# Altium schematic sheet RS485_Isolator_2_click_v101.SchDoc(RS485_Isolator_2_click_v101)
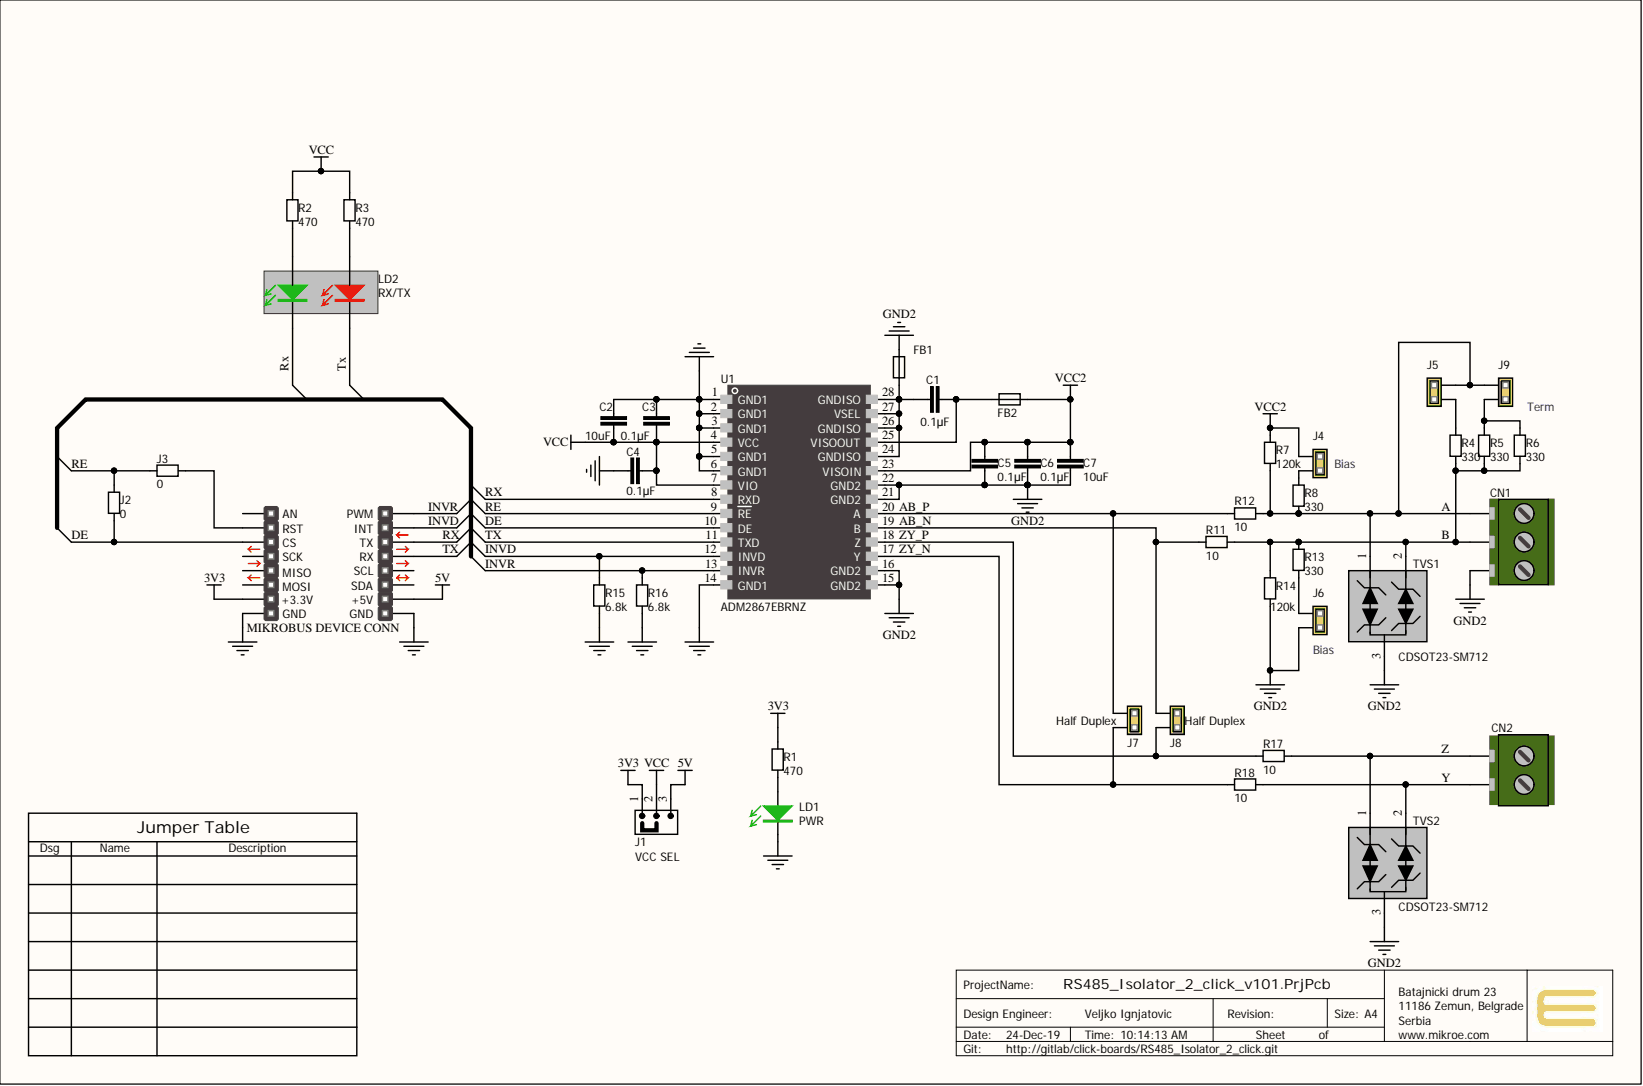
[diagram p0: RS485_Isolator_2_click_v101.SchDoc]

## Parts
C1  0.1µF  [CAPC1005X55N-0402-NoOverlay]
C2  10uF  [CAPC1005X55N-0402-NoOverlay]
C3  0.1µF  [CAPC1005X55N-0402-NoOverlay]
C4  0.1µF  [CAPC1005X55N-0402-NoOverlay]
C5  0.1µF  [CAPC1005X55N-0402-NoOverlay]
C6  0.1µF  [CAPC1005X55N-0402-NoOverlay]
C7  10uF  [CAPC1005X55N-0402-NoOverlay]
CN1  #NAME?  [SCREW TERMINAL 3P 2.54]  (value not drawn)
CN2  Manufacturer_Part_Number  [SCREW TERMINAL 2.54]  (value not drawn)
FB1  FERRITE  [INDC1005X60N-0402-NOOVERLAY]  (value not drawn)
FB2  FERRITE  [INDC1005X60N-0402-NOOVERLAY]  (value not drawn)
J1  VCC SEL  [JUMPER-0805-V2-12]
J2  0  [RESC2013X38N-0805-NOOVERLAY]  (no BOM)
J3  0  [RESC2013X38N-0805-NOOVERLAY]
J4  HM1x2 Jumper  [HDR1X2]  (value not drawn)
J5  HM1x2 Jumper  [HDR1X2]  (value not drawn)
J6  HM1x2 Jumper  [HDR1X2]  (value not drawn)
J7  Half Duplex  [HDR1X2]
J8  Half Duplex  [HDR1X2]
J9  HM1x2 Jumper  [HDR1X2]  (value not drawn)
LD1  PWR  [DIOGREENC2013X120N-0805-NoOverlay]
LD2  RX/TX  [LED0603RG - nooverlay]
MBD1  MIKROBUS DEVICE CONN  [New Click Template_LARGE-WG]  (no BOM)
R1  470  [RESC2013X38N-0805-NOOVERLAY]
R2  470  [RESC1005X40N-0402-NOOVERLAY]
R3  470  [RESC1005X40N-0402-NOOVERLAY]
R4  330  [RESC1005X40N-0402-NOOVERLAY]
R5  330  [RESC1005X40N-0402-NOOVERLAY]
R6  330  [RESC1005X40N-0402-NOOVERLAY]
R7  120k  [RESC1005X40N-0402-NOOVERLAY]
R8  330  [RESC1005X40N-0402-NOOVERLAY]
R11  10  [RESC1005X40N-0402-NOOVERLAY]
R12  10  [RESC1005X40N-0402-NOOVERLAY]
R13  330  [RESC1005X40N-0402-NOOVERLAY]
R14  120k  [RESC1005X40N-0402-NOOVERLAY]
R15  6.8k  [RESC1005X40N-0402-NOOVERLAY]
R16  6.8k  [RESC1005X40N-0402-NOOVERLAY]
R17  10  [RESC1005X40N-0402-NOOVERLAY]
R18  10  [RESC1005X40N-0402-NOOVERLAY]
TVS1  CDSOT23-SM712  [SOT95P240X110-3N]
TVS2  CDSOT23-SM712  [SOT95P240X110-3N]
U1  ADM2867EBRNZ  [SOP65P1030X265-28N-NoOverlay]

## Nets
3V3: J1.1 MBD1.7(+3.3V) R1.2
5V: J1.3 MBD1.10(+5V)
GND: C2.2 C3.2 C4.2 LD1.1 MBD1.8(GND) MBD1.9(GND) R15.2 R16.2 U1.1(GND1) U1.2(GND1) U1.3(GND1) U1.5(GND1) U1.6(GND1) U1.14(GND1)
GND2: C5.2 C6.2 C7.1 CN1.1 FB1.1 J6.1 R14.1 TVS1.3 TVS2.3 U1.15(GND2) U1.16(GND2) U1.21(GND2) U1.22(GND2)
VCC: C2.1 C3.1 C4.1 J1.2 R2.2 R3.2 U1.4(VCC) U1.7(VIO)
VCC2: C5.1 C6.1 C7.2 FB2.1 J4.1 R7.2 U1.23(VISOIN)
A: CN1.3 J5.1 J9.1 R7.1 R8.1 R12.2 TVS1.1
AB_N: J8.2 R11.1 U1.19(B)
AB_P: J7.2 R12.1 U1.20(A)
B: CN1.2 R4.1 R5.1 R6.1 R11.2 R13.2 R14.2 TVS1.2
DE: J2.1 MBD1.3(CS) U1.10(DE)
INVD: MBD1.15(INT) R15.1 U1.12(INVD)
INVR: MBD1.16(PWM) R16.1 U1.13(INVR)
RE: J2.2 J3.2 U1.9(RE)
Rx: LD2.3 MBD1.14(TX) U1.8(RXD)
Tx: LD2.4 MBD1.13(RX) U1.11(TXD)
Y: CN2.1 R18.2 TVS2.2
Z: CN2.2 R17.2 TVS2.1
ZY_N: J7.1 R18.1 U1.17(Y)
ZY_P: J8.1 R17.1 U1.18(Z)
NetC1_1: C1.1 FB1.2 U1.24(GNDISO) U1.26(GNDISO) U1.27(VSEL) U1.28(GNDISO)
NetC1_2: C1.2 FB2.2 U1.25(VISOOUT)
NetJ3_1: J3.1 MBD1.2(RST)
NetJ4_2: J4.2 R8.2
NetJ5_2: J5.2 R4.2
NetJ6_2: J6.2 R13.1
NetJ9_2: J9.2 R5.2 R6.2
NetLD1_2: LD1.2 R1.1
NetLD2_1: LD2.1 R2.1
NetLD2_2: LD2.2 R3.1
N00012:

Not connected: MBD1 1(AN) 4(SCK) 5(MISO) 6(MOSI) 11(SDA) 12(SCL)

## Library data of the parts
C1 C3-C6 = 2911010025755: CAP CER 0.1UF 10V X5R 0402; Manufacturer Part Number: LMK105BJ104KV-F; Manufacturer: Taiyo Yuden; Tolerance: ±10%; Recommended Rating: Platinum; item_id: 3484; id: 63; Database Table Name: capacitors; Assembly: SMD; Voltage Rated: 10V; Temperature Coefficient: X5R; Part Type: Capacitors; Package Case: 0402 (1005 Metric); Library Name: ME-Altium Lib.SVNDbLib; Family: CERAMIC CAPACITOR; Datasheet URL: http://ds.yuden.co.jp/TYCOMPAS/ut/detail.do?productNo=LMK105BJ104KV-F&fileName=LMK105BJ104KV-F_SS&mode=specSheetDownload; Component Kind: Standard; Category: Capacitors; Capacitance: 0.1µF; Barcode: 2911010025755
C2 C7 = 0100000099759: CAP CER 10UF 10V X5R 0402; Manufacturer Part Number: CL05A106MP5NUNC; Manufacturer: Samsung Electro-Mechanics America, Inc.; Tolerance: ±20%; item_id: 9089; id: 547; Database Table Name: capacitors; Assembly: SMD; Voltage Rated: 10V; Temperature Coefficient: X5R; Part Type: Capacitors; Package Case: 0402 (1005 Metric); Library Name: ME-Altium Lib.SVNDbLib; Family: CERAMIC CAPACITOR; Datasheet URL: http://media.digikey.com/pdf/Data%20Sheets/Samsung%20PDFs/CL_Series_MLCC_ds.pdf; Component Kind: Standard; Category: Capacitors; Capacitance: 10uF; Barcode: 0100000099759
CN1 = 3500000151421: TERM BLOCK 3POS SIDE ENT 2.54MM; Manufacturer: TE Connectivity AMP Connectors; Positions Per Level: 3; Pitch: 0.100" (2.54mm); Number of Levels: 1; item_id: 13278; id: 498; Assembly: TH; Part Type: Connectors, Electromechanical; Mounting Type: Through Hole; Library Name: connectors_electromechanical.IntLib; Features: -; Family: TERMINAL BLOCK; Datasheet URL: http://www.te.com/commerce/DocumentDelivery/DDEController?Action=srchrtrv&DocNm=282834&DocType=Customer+Drawing&DocLang=English; Component Kind: Standard; Color: Green; Category: Connectors, Interconnects; Barcode: 3500000151421
CN2 = 0100000003800: TERM BLOCK 2POS SIDE ENT 2.54MM; Manufacturer: TE Connectivity AMP Connectors; Positions Per Level: 2; Pitch: 0.100" (2.54mm); Number of Levels: 1; item_id: 646; id: 56; Assembly: TH; Part Type: Connectors, Electromechanical; Mounting Type: Through Hole; Library Name: connectors_electromechanical.IntLib; Features: -; Family: TERMINAL BLOCK; Datasheet URL: http://www.te.com/commerce/DocumentDelivery/DDEController?Action=srchrtrv&DocNm=282834&DocType=Customer+Drawing&DocLang=English; Component Kind: Standard; Color: Green; Category: Connectors, Interconnects; Barcode: 0100000003800
FB1 FB2 = 3500000178398: FERRITE BEAD 1.8 KOHM 0402 1LN; Manufacturer Part Number: BLM15HD182SN1D; Manufacturer: Murata Electronics North America; item_id: 15974; Impedance Frequency: 1.8 kOhms @ 100MHz; id: 227; Filter Type: Signal Line; Assembly: SMD; Part Type: Inductor, Transf, Filter, Ferrite; Package Case: 0402 (1005 Metric); Family: FERRITE; Datasheet URL: https://www.murata.com/en-global/products/productdata/8796740223006/ENFA0024.pdf; Component Kind: Standard; Category: Filters; Barcode: 3500000178398; Library Name: inductor_transf_filter_ferrite.IntLib
J1 = SEL JMP 0805 SMD: Manufacturer Part Number: RC0805JR-070RL; Manufacturer: Yageo; Tolerance: Jumper; Resistance: 0.0; Power: 0.125W, 1/8W; Barcode: 0100000003947; Assembly: SMD; Temperature Coefficient: -; Part Type: Resistors; Package Case: 0805 (2012 Metric); Library Name: ME-Integrated_Library.IntLib; Datasheet URL: http://www.yageo.com/NewPortal/yageodocoutput?fileName=/pdf/R-Chip/PYu-RC_Group_51_RoHS_L_3.pdf; Component Kind: Standard; Category: Resistors
J2 J3 = 0100000003947: RES SMD 0.0 OHM JUMPER 1/8W 0805; Manufacturer Part Number: RC0805JR-070RL; Manufacturer: Yageo; Power: 0.125W, 1/8W; item_id: 660; id: 233; Database Table Name: resistors; Tolerance: Jumper; Temperature Coefficient: -; Resistance: 0; Part Type: Resistors; Package Case: 0805 (2012 Metric); Library Name: ME-Altium Lib.SVNDbLib; Family: RESISTOR; Datasheet URL: http://www.yageo.com/NewPortal/yageodocoutput?fileName=/pdf/R-Chip/PYu-RC_Group_51_RoHS_L_3.pdf; Category: Resistors; Barcode: 0100000003947; Assembly: SMD
  J3: Component Kind: Standard
J4-J9 = JUMPER MaleHeader 1x2: Jumper; Manufacturer Part Number: 61300211121; Manufacturer: Wurth Electronics Inc; Pitch: 0.100" (2.54mm); Number of Positions: 2; Connector Type: Header, Unshrouded; Barcode: 0100000002155; Assembly: TH; Part Type: Connectors, Electromechanical; Number of Rows: 1; Mounting Type: Through Hole; Library Name: ME-Integrated_Library.IntLib; Features: -; Family: HEADER MALE; Datasheet URL: http://katalog.we-online.de/em/datasheet/6130xx11121.pdf; Contact Type: Male Pin; Contact Finish: Gold; Component Kind: Standard; Color: Black; Category: Connectors, Interconnects
LD1 = 2911010024338: LED GREEN CLEAR 0805 SMD; Manufacturer Part Number: APT2012SGC; Manufacturer: Kingbright; Wavelength: 568nm; Voltage Forward: 2.2V; Recommended Rating: Platinum; Lens Color: Colorless; item_id: 3342; id: 28; Database Table Name: optoelectronics; Part Type: Optoelectronics; Package Case: 0805 (2012 Metric); Library Name: ME-Altium Lib.SVNDbLib; Lens Transparency: Clear; Family: LED; Datasheet URL: http://www.kingbrightusa.com/images/catalog/SPEC/APT2012SGC.pdf; Component Kind: Standard; Color: Green; Category: Optoelectronics; Barcode: 2911010024338; Assembly: SMD
LD2 = 3500000163875: LED GREEN/RED DIFFUSED 0603 SMD; Manufacturer Part Number: HSMF-C165; Manufacturer: Broadcom Limited; Voltage Forward: 2.2V Green, 1.95V Red; Lens Color: White; item_id: 14522; id: 177; Color: Green, Red; Part Type: Optoelectronics; Package Case: 0603 (1608 Metric); Library Name: optoelectronics.IntLib; Lens Transparency: Diffused; Family: LED; Datasheet URL: https://docs.broadcom.com/docs/AV02-0584EN; Component Kind: Standard; Category: Optoelectronics; Barcode: 3500000163875; Assembly: SMD
MBD1 = MIKROBUS DEVICE CONN: mikroBUS Target Header; Library Name: ME-Integrated_Library.IntLib
R1 = 0100000007921: RES SMD 470 OHM 1% 1/8W 0805; Manufacturer Part Number: RC0805FR-07470RL; Manufacturer: Yageo; Temperature Coefficient: ±100ppm/°C; Recommended Rating: Platinum; Power: 0.125W, 1/8W; item_id: 1082; id: 207; Database Table Name: resistors; Tolerance: ±1%; Resistance: 470; Part Type: Resistors; Package Case: 0805 (2012 Metric); Library Name: ME-Altium Lib.SVNDbLib; Family: RESISTOR; Datasheet URL: http://www.yageo.com/NewPortal/yageodocoutput?fileName=/pdf/R-Chip/PYu-RC_Group_51_RoHS_L_3.pdf; Component Kind: Standard; Category: Resistors; Barcode: 0100000007921; Assembly: SMD
R2 R3 = 2911010039684: RES SMD 470 OHM 1% 1/10W 0402; Manufacturer Part Number: ERJ-2RKF4700X; Manufacturer: Panasonic Electronic Components; Temperature Coefficient: ±100ppm/°C; Power: 0.1W, 1/10W; item_id: 4638; id: 53; Database Table Name: resistors; Tolerance: ±1%; Resistance: 470; Part Type: Resistors; Package Case: 0402 (1005 Metric); Library Name: ME-Altium Lib.SVNDbLib; Family: RESISTOR; Datasheet URL: http://industrial.panasonic.com/www-cgi/jvcr13pz.cgi?E+PZ+3+AOA0002+ERJ2RKF4700X+7+WW; Component Kind: Standard; Category: Resistors; Barcode: 2911010039684; Assembly: SMD
R4-R6 R8 R13 = 0100000104545: RES SMD 330 OHM 1% 1/16W 0402; Manufacturer Part Number: RC0402FR-07330RL; Manufacturer: Yageo; Temperature Coefficient: ±100ppm/°C; Power: 0.063W, 1/16W; item_id: 9568; id: 332; Database Table Name: resistors; Tolerance: ±1%; Resistance: 330; Part Type: Resistors; Package Case: 0402 (1005 Metric); Library Name: ME-Altium Lib.SVNDbLib; Family: RESISTOR; Datasheet URL: http://www.yageo.com/documents/recent/PYu-RC_Group_51_RoHS_L_04.pdf; Component Kind: Standard; Category: Resistors; Barcode: 0100000104545; Assembly: SMD
R7 R14 = 0100000077740: RES SMD 120K OHM 1% 1/16W 0402; Manufacturer Part Number: RMCF0402FT120K; Manufacturer: Stackpole Electronics Inc.; Temperature Coefficient: ±100ppm/°C; Power: 0.063W, 1/16W; item_id: 6918; id: 4; Database Table Name: resistors; Tolerance: ±1%; Resistance: 120k; Part Type: Resistors; Package Case: 0402 (1005 Metric); Library Name: ME-Altium Lib.SVNDbLib; Family: RESISTOR; Datasheet URL: http://www.seielect.com/Catalog/SEI-RMCF_RMCP.pdf; Component Kind: Standard; Category: Resistors; Barcode: 0100000077740; Assembly: SMD
R11 R12 R17 R18 = 2911010039677: RES SMD 10 OHM 5% 1/10W 0402; Manufacturer Part Number: ERJ-2GEJ100X; Manufacturer: Panasonic Electronic Components; Temperature Coefficient: ±200ppm/°C; Recommended Rating: Silver; Power: 0.1W, 1/10W; item_id: 4637; id: 54; Database Table Name: resistors; Tolerance: ±5%; Resistance: 10; Part Type: Resistors; Package Case: 0402 (1005 Metric); Library Name: ME-Altium Lib.SVNDbLib; Family: RESISTOR; Datasheet URL: http://industrial.panasonic.com/www-cgi/jvcr13pz.cgi?E+PZ+3+AOA0001+ERJ2GEJ100X+7+WW; Component Kind: Standard; Category: Resistors; Barcode: 2911010039677; Assembly: SMD
R15 R16 = 3500000173218: RES SMD 6.8K OHM 1% 1/16W 0402; Manufacturer Part Number: AC0402FR-076K8L; Manufacturer: Yageo; Temperature Coefficient: ±100ppm/°C; Power: 0.063W, 1/16W; item_id: 15456; id: 908; Database Table Name: resistors; Tolerance: ±1%; Resistance: 6.8k; Part Type: Resistors; Package Case: 0402 (1005 Metric); Library Name: ME-Altium Lib.SVNDbLib; Family: RESISTOR; Datasheet URL: http://www.yageo.com/NewPortal/yageodocoutput?fileName=/pdf/R-Chip/PYu-AC_51_RoHS_L_6.pdf; Component Kind: Standard; Category: Resistors; Barcode: 3500000173218; Assembly: SMD
TVS1 TVS2 = 3500000142375: TVS DIODE 7VWM/12VWM SOT23; Manufacturer: Bourns Inc.; Voltage  Reverse Standoff: 7V (Max), 12V (Max); Voltage Clamping Max Ipp: 14V, 26V; Voltage  Breakdown: 7.5V, 13.3V; item_id: 12373; id: 228; Current Peak Pulse: 17A (8/20µs); Assembly: SMD; Part Type: Discrete Semiconductors; Package Case: TO-236-3, SC-59, SOT-23-3; Manufacturer Part Number: CDSOT23-SM712; Library Name: discrete_semiconductors.IntLib; Family: TVS DIODE; Datasheet URL: http://www.bourns.com/docs/Product-Datasheets/CDSOT23-SM712.pdf; Component Kind: Standard; Category: Circuit Protection; Barcode: 3500000142375
U1 = 3500000247353: Manufacturer: Analog Devices Inc.; Protocol: RS485; item_id: 22880; id: 2830; Database Table Name: integrated_circuits; Assembly: SMD; Part Type: Integrated Circuits; Package Case: 28-SOIC; Manufacturer Part Number: ADM2867EBRNZ; Library Name: ME-Altium Lib.SVNDbLib; Family: Drivers, Receivers, Transceivers; Datasheet URL: https://www.analog.com/media/en/technical-documentation/data-sheets/ADM2867E.pdf; Component Kind: Standard; Barcode: 3500000247353
